# Altium schematic sheet USBHub.SchDoc
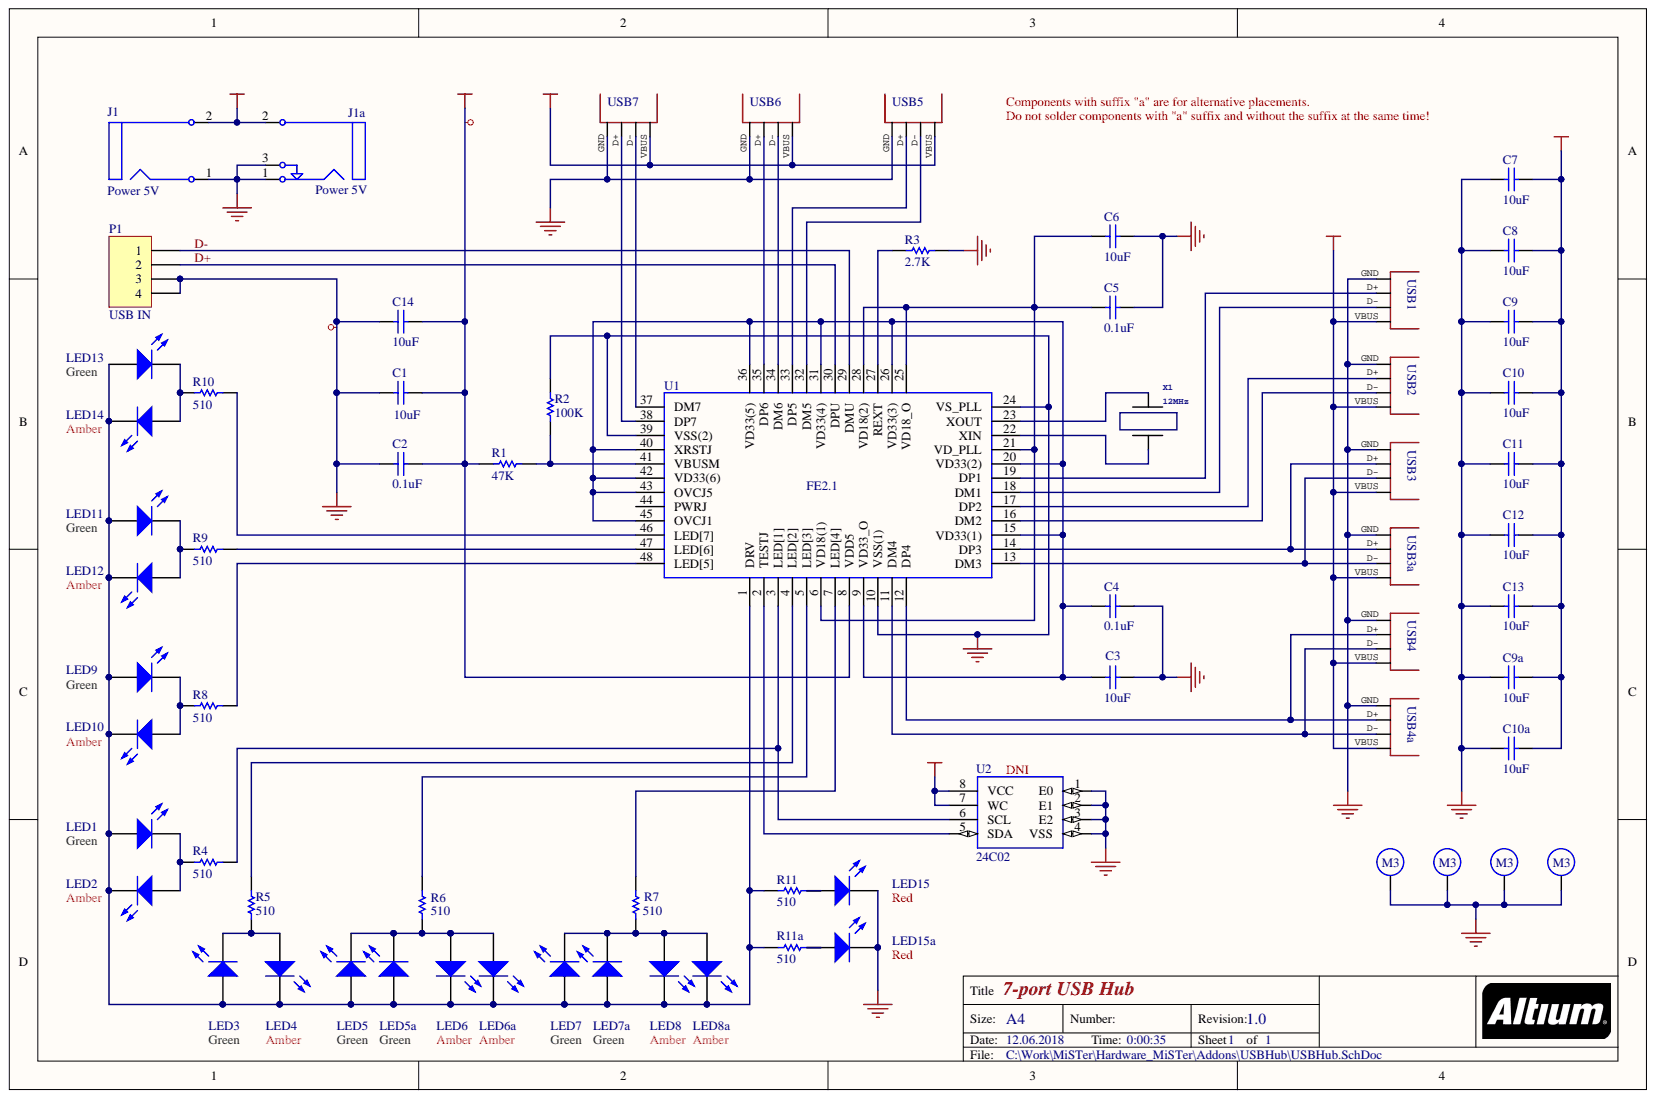
[diagram p0: USBHub.SchDoc]

## Parts
C1  value 10uF  [C0805]  (hidden comment: C0805)
C2  value 0.1uF  [C0805]  (hidden comment: C0805)
C3  value 10uF  [C0805]  (hidden comment: C0805)
C4  value 0.1uF  [C0805]  (hidden comment: C0805)
C5  value 0.1uF  [C0805]  (hidden comment: C0805)
C6  value 10uF  [C0805]  (hidden comment: C0805)
C7  value 10uF  [C0805]  (hidden comment: C0805)
C8  value 10uF  [C0805]  (hidden comment: C0805)
C9  value 10uF  [C0805]  (hidden comment: C0805)
C9a  value 10uF  [C0805]  (hidden comment: C0805)
C10  value 10uF  [C0805]  (hidden comment: C0805)
C10a  value 10uF  [C0805]  (hidden comment: C0805)
C11  value 10uF  [C0805]  (hidden comment: C0805)
C12  value 10uF  [C0805]  (hidden comment: C0805)
C13  value 10uF  [C0805]  (hidden comment: C0805)
C14  value 10uF  [C0805]  (hidden comment: C0805)
H1  M3  [M3]
H2  M3  [M3]
H3  M3  [M3]
H4  M3  [M3]
J1  Power 5V  [PWR-2mm 2pins]
J1a  Power 5V  [PWR-2mm]
LED1  Green  [LEDG0805]
LED2  Amber  [LEDGL0805]
LED3  Green  [LEDG0805]
LED4  Amber  [LEDGL0805]
LED5  Green  [LEDG0805]
LED5a  Green  [LEDG0805]
LED6  Amber  [LEDGL0805]
LED6a  Amber  [LEDGL0805]
LED7  Green  [LEDG0805]
LED7a  Green  [LEDG0805]
LED8  Amber  [LEDGL0805]
LED8a  Amber  [LEDGL0805]
LED9  Green  [LEDG0805]
LED10  Amber  [LEDGL0805]
LED11  Green  [LEDG0805]
LED12  Amber  [LEDGL0805]
LED13  Green  [LEDG0805]
LED14  Amber  [LEDGL0805]
LED15  Red  [LEDR0805]
LED15a  Red  [LEDR0805]
P1  USB IN  [HDR1X4]
R1  47K  [R0805]
R2  100K  [R0805]
R3  2.7K  [R0805]
R4  510  [R0805]
R5  510  [R0805]
R6  510  [R0805]
R7  510  [R0805]
R8  510  [R0805]
R9  510  [R0805]
R10  510  [R0805]
R11  510  [R0805]
R11a  510  [R0805]
U1  FE2.1  [LQFP48]
U2  24C02  [SOIC127P600X175-8N]
USB1  USBA-PTH  [USB-A-F-PTH]  (value not drawn)
USB2  USBA-PTH  [USB-A-F-PTH]  (value not drawn)
USB3  USBA-PTH  [USB-A-F-PTH]  (value not drawn)
USB3a  USBA-PTH  [USB-A-F-PTH]  (value not drawn)
USB4  USBA-PTH  [USB-A-F-PTH]  (value not drawn)
USB4a  USBA-PTH  [USB-A-F-PTH]  (value not drawn)
USB5  USBA-PTH  [USB-A-F-PTH]  (value not drawn)
USB6  USBA-PTH  [USB-A-F-PTH]  (value not drawn)
USB7  USBA-PTH  [USB-A-F-PTH]  (value not drawn)
X1  12MHz  [XTAL_G5032]

## Nets
GND: C1.2 C2.2 C3.2 C4.2 C5.2 C6.2 C7.2 C8.2 C9.2 C9a.2 C10.2 C10a.2 C11.2 C12.2 C13.2 C14.2 H1.1 H2.1 H3.1 H4.1 J1.1 J1a.1 J1a.3 LED15.2 LED15a.2 P1.3 P1.4 R2.1 R3.2 U1.10(VSS(1)) U1.24(VS_PLL) U1.39(VSS(2)) U2.1(E0) U2.2(E1) U2.3(E2) U2.4(VSS) USB1.GND USB2.GND USB3.GND USB3a.GND USB4.GND USB4a.GND USB5.GND USB6.GND USB7.GND
VCC: C1.1 C2.1 C7.1 C8.1 C9.1 C9a.1 C10.1 C10a.1 C11.1 C12.1 C13.1 C14.1 J1.2 J1a.2 R1.2 U1.8(VDD5) U2.7(WC) U2.8(VCC) USB1.VBUS USB2.VBUS USB3.VBUS USB3a.VBUS USB4.VBUS USB4a.VBUS USB5.VBUS USB6.VBUS USB7.VBUS
D+: P1.2 U1.30(DPU)
D-: P1.1 U1.29(DMU)
NetC3_1: C3.1 C4.1 U1.9(VD33_O) U1.15(VD33(1)) U1.20(VD33(2)) U1.26(VD33(3)) U1.31(VD33(4)) U1.36(VD33(5)) U1.40(XRSTJ) U1.42(VD33(6)) U1.43(OVCJ5) U1.45(OVCJ1)
NetC5_1: C5.1 C6.1 U1.6(VD18(1)) U1.21(VD_PLL) U1.25(VD18_O) U1.28(VD18(2))
NetLED1_1: LED1.1 LED2.2 LED3.1 LED4.2 LED5.1 LED5a.1 LED6.2 LED6a.2 LED7.1 LED7a.1 LED8.2 LED8a.2 LED9.1 LED10.2 LED11.1 LED12.2 LED13.1 LED14.2 R11.2 R11a.2 U1.1(DRV)
NetLED1_2: LED1.2 LED2.1 R4.2
NetLED3_2: LED3.2 LED4.1 R5.2
NetLED5_2: LED5.2 LED5a.2 LED6.1 LED6a.1 R6.2
NetLED7_2: LED7.2 LED7a.2 LED8.1 LED8a.1 R7.2
NetLED9_2: LED9.2 LED10.1 R8.2
NetLED11_2: LED11.2 LED12.1 R9.2
NetLED13_2: LED13.2 LED14.1 R10.2
NetLED15_1: LED15.1 R11.1
NetLED15a_1: LED15a.1 R11a.1
NetR1_1: R1.1 R2.2 U1.41(VBUSM)
NetR3_1: R3.1 U1.27(REXT)
NetR4_1: R4.1 U1.3(LED[1]) U2.6(SCL)
NetR5_1: R5.1 U1.4(LED[2])
NetR6_1: R6.1 U1.5(LED[3])
NetR7_1: R7.1 U1.7(LED[4])
NetR8_1: R8.1 U1.48(LED[5])
NetR9_1: R9.1 U1.47(LED[6])
NetR10_1: R10.1 U1.46(LED[7])
NetU1_2: U1.2(TESTJ) U2.5(SDA)
NetU1_11: U1.11(DM4) USB4.D- USB4a.D-
NetU1_12: U1.12(DP4) USB4.D+ USB4a.D+
NetU1_13: U1.13(DM3) USB3.D- USB3a.D-
NetU1_14: U1.14(DP3) USB3.D+ USB3a.D+
NetU1_16: U1.16(DM2) USB2.D-
NetU1_17: U1.17(DP2) USB2.D+
NetU1_18: U1.18(DM1) USB1.D-
NetU1_19: U1.19(DP1) USB1.D+
NetU1_22: U1.22(XIN) X1.2
NetU1_23: U1.23(XOUT) X1.1
NetU1_32: U1.32(DM5) USB5.D-
NetU1_33: U1.33(DP5) USB5.D+
NetU1_34: U1.34(DM6) USB6.D-
NetU1_35: U1.35(DP6) USB6.D+
NetU1_37: U1.37(DM7) USB7.D-
NetU1_38: U1.38(DP7) USB7.D+

Not connected: U1 44(PWRJ)

## Library data of the parts
C1-C9 C9a C10 C10a C11-C14 = C0805: Ceramic Chip Capacitor - Standard; Note: Footprint corresponds to dimensions for bulk cassette packaging. This case size has tightened tolerances.; Code_IPC: 2012[0805]; Code_JEITA: 0805; Component Kind: Standard; ComponentLink1Description: Manufacturer Link; ComponentLink1URL: http://www.kemet.com/; ComponentLink2Description: Datasheet; ComponentLink2URL: http://www.kemet.com/kemet/web/homepage/kechome.nsf/vapubfilesname/F3102TA.pdf/$file/F3102TA.pdf; DatasheetDocument: Mar-2001; LatestRevisionDate: 19-Mar-2004; LatestRevisionNote: Silkscreen size reduced.; Library Name: KEMET Chip Capacitor.IntLib; PackageDocument: Mar-2001; PackageReference: 0805; Published: 17-Jul-2002; Signal Integrity: C0805; Simulation: CAP; Publisher: Altium Limited
J1 = Powerjack_2p: Component Kind: Standard; Library Name: lib.SchLib
J1a = Powerjack: Component Kind: Standard; Library Name: lib.SchLib
LED1-LED5 LED5a LED6 LED6a LED7 LED7a LED8 LED8a LED9-LED15 LED15a = LED2: Typical RED, GREEN, YELLOW, AMBER GaAs LED; Component Kind: Standard; LatestRevisionDate: 17-Jul-2002; LatestRevisionNote: Re-released for DXP Platform.; Library Name: Miscellaneous Devices.IntLib; PackageDescription: SM LED; 2 Flat Leads; PackageReference: 3.2X1.6X1.1; PackageVersion: Feb-2002; Published: 8-Jun-2000; Simulation: LED2; Publisher: Altium Limited
P1 = Header 4: Header, 4-Pin; Component Kind: Standard; LatestRevisionDate: 17-Jul-2002; LatestRevisionNote: Re-released for DXP Platform.; Library Name: Miscellaneous Connectors.IntLib; Signal Integrity: Connector; Publisher: Altium Limited
R1-R11 R11a = ERJ6RS: Low Ohmic Value Thick Film Chip Resistor, 0.1 Ohm to 0.2 Ohm Range, 1%, 2% and 5% Tolerance, 0805 Size, 0.125 W; Code_IPC: 2012 [0805]; Component Kind: Standard; ComponentLink1Description: Manufacturer Link; ComponentLink1URL: http://www.panasonic.com/industrial/components/resistive_products.htm; ComponentLink2Description: Datasheet; ComponentLink2URL: http://www.panasonic.com/industrial/components/pdf/AOA0000CE3.pdf; LatestRevisionDate: 19-Mar-2004; LatestRevisionNote: Silkscreen size reduced.; Library Name: lib.PcbLib; PackageDocument: Mar-2003; PackageReference: 6-0805; Published: 17-Dec-2003; Signal Integrity: Res; Simulation: RESISTOR; Publisher: Altium Limited
U1 = FE2.1_QFP: USB 2.0 HIGH SPEED 7-PORT HUB CONTROLLER; Manufacturer_Name: Terminus Technology; Geometry.Height: 1.6mm; Component Kind: Standard; Datasheet Link: http://www.35ic.net/ui/docs/assets/img/1458708200035.PDF; Library Name: lib.SchLib; Manufacturer_Part_Number: FE2.1_QFP
U2 = M24C02: 24C02, EEPROM Memory 2kbit,, 256 x, 8bit, Serial-I2C, 900ns 2.5  5.5 V, 8-Pin SOIC; Manufacturer_Name: STMicroelectronics; Manufacturer_Part_Number: M24C02-WMN6P; Allied_Number: 70013682; Geometry.Height: 1.75mm; Allied Price/Stock: http://www.alliedelec.com/stmicroelectronics-m24c02-wmn6p/70013682/; Component Kind: Standard; Datasheet Link: http://www.st.com/st-web-ui/static/active/en/resource/technical/document/datasheet/DM00071904.pdf; Library Name: lib.SchLib; RS Part Number: 7140843; RS Price/Stock: http://uk.rs-online.com/web/p/products/7140843
X1 = CRYSTALHC49UP: PTH: ...   SMD: ...   Cylinder: CMR200T-32.768KDZBT; Component Kind: Standard; Library Name: lib.SchLib
H1-H4 = M3: Component Kind: Standard; Library Name: lib.SchLib
USB1-USB3 USB3a USB4 USB4a USB5-USB7 = USBA-PTH: Component Kind: Standard; Library Name: lib.SchLib; USB: USB
